# Altium schematic sheet TIA.SchDoc
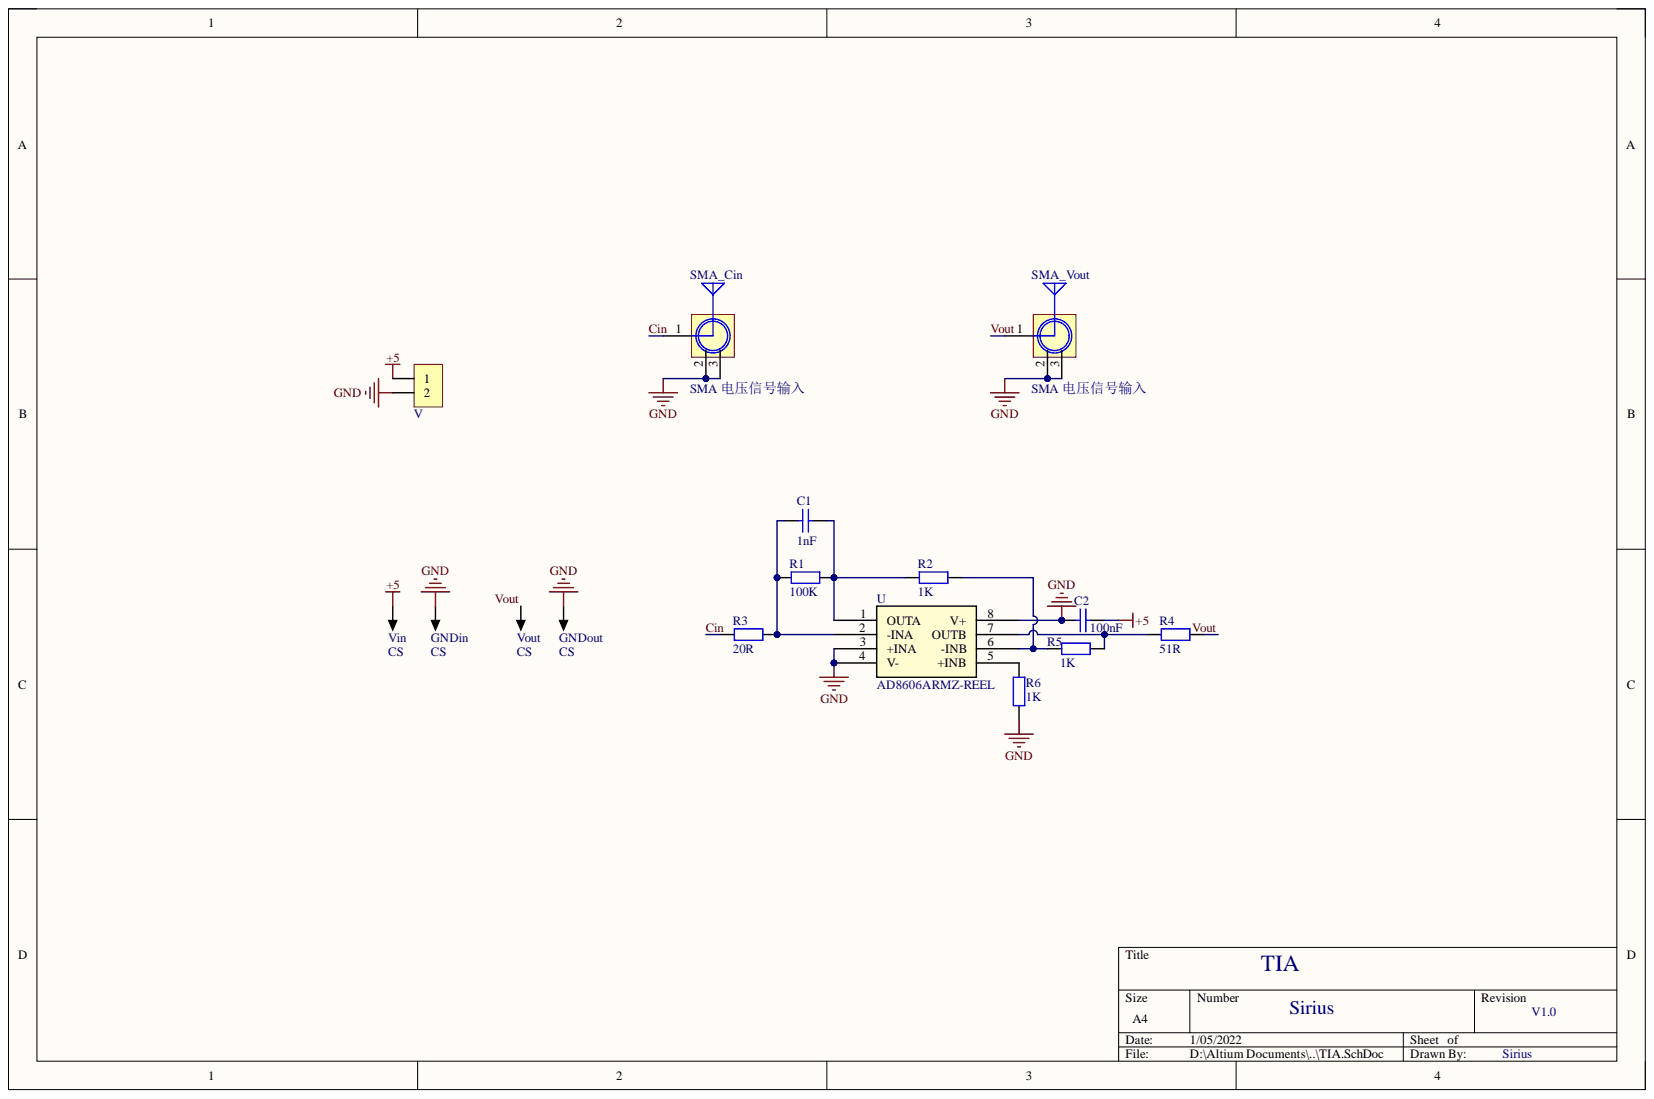
[diagram p0: TIA.SchDoc]

## Parts
C1  1nF  [C0603_L]
C2  100nF  [C0603_L]
GNDin  CS  [R100]
GNDout  CS  [R100]
R1  100K  [R0603_L]
R2  1K  [R0603_L]
R3  20R  [R1206_L]
R4  51R  [R0603_L]
R5  1K  [R0603_L]
R6  1K  [R0603_L]
SMA_Cin  SMA 电压信号输入  [SMA_FR]
SMA_Vout  SMA 电压信号输入  [SMA_FR]
U  AD8606ARMZ-REEL  [RM_8_ADI-L]
V  HDR-1X2  [HDR2.54-LI-2P]  (value not drawn)
Vin  CS  [R100]
Vout  CS  [R100]

## Nets
+5: C2.2 V.1 Vin.1
GND: C2.1 GNDin.1 GNDout.1 R6.1 SMA_Cin.2 SMA_Cin.3 SMA_Vout.2 SMA_Vout.3 U.3(+INA) U.4(V-) U.8(V+) V.2
Cin: R3.1 SMA_Cin.1
Vout: R4.2 SMA_Vout.1 Vout.1
NetC1_1: C1.1 R1.2 R2.1 U.1(OUTA)
NetC1_2: C1.2 R1.1 R3.2 U.2(-INA)
NetR2_2: R2.2 R5.2 U.6(-INB)
NetR4_1: R4.1 R5.1 U.7(OUTB)
NetR6_2: R6.2 U.5(+INB)

## Library data of the parts
C1 C2 = C: 贴片电容; BOM名称: 无极性贴片电容; 联系卖家，免费查找封装: 唯一出售店铺网址https://shop463601444.taobao.com; Component Kind: Standard; Library Name: 0.1 - 电阻-电容-电感-晶振-晶体-磁珠-电位器.SchLib
GNDin GNDout Vin Vout = CS: 探针焊盘; 封装库来自淘宝卖家ID：黄晶宝石: 其他店铺购入均为盗版假冒封装库，请立即申请退款; 联系卖家，免费查找封装: 唯一出售店铺网址https://shop463601444.taobao.com; BOM名称: 探针焊盘; Component Kind: Standard; Library Name: 0.5 - 按键-开关-蜂鸣器-保险丝-电池-测试点-Mark点.SchLib
R1-R6 = R: 贴片电阻; 联系卖家，免费查找封装: 唯一出售店铺网址https://shop463601444.taobao.com; BOM名称: 贴片电阻; Component Kind: Standard; Library Name: 0.1 - 电阻-电容-电感-晶振-晶体-磁珠-电位器.SchLib
SMA_Cin SMA_Vout = SMA: SMA-2.4G射频天线接口; 联系卖家，免费技术支持: 唯一出售店铺网址https://shop463601444.taobao.com; BOM名称: SMA-2.4G射频天线接口; Component Kind: Standard; Library Name: 1.7 - WiFi天线-SMA射频座.SchLib
U = AD8606ARMZ-REEL: No Description Available; Mfr_Name: Analog Devices Inc; Component Kind: Standard; Manufacturer_Part_Number: AD8606ARMZ-REEL; RefDes: RefDes; Type: DEV; Copyright: Copyright (C) 2022 Ultra Librarian. All rights reserved.; Library Name: Extra Sch.SchLib
V = Header 2: 2P接插件; 封装库来自淘宝卖家 ID：黄晶宝石: 其他店铺购入均为盗版假冒封装库; 联系卖家，免费查找封装: 唯一出售店铺网址https://shop463601444.taobao.com; BOM名称: 2P接插件; Component Kind: Standard; Library Name: 5.5 - 2.54mm(100mil)连接器(XH2.54).SchLib
